# Altium schematic sheet power.SchDoc(power)
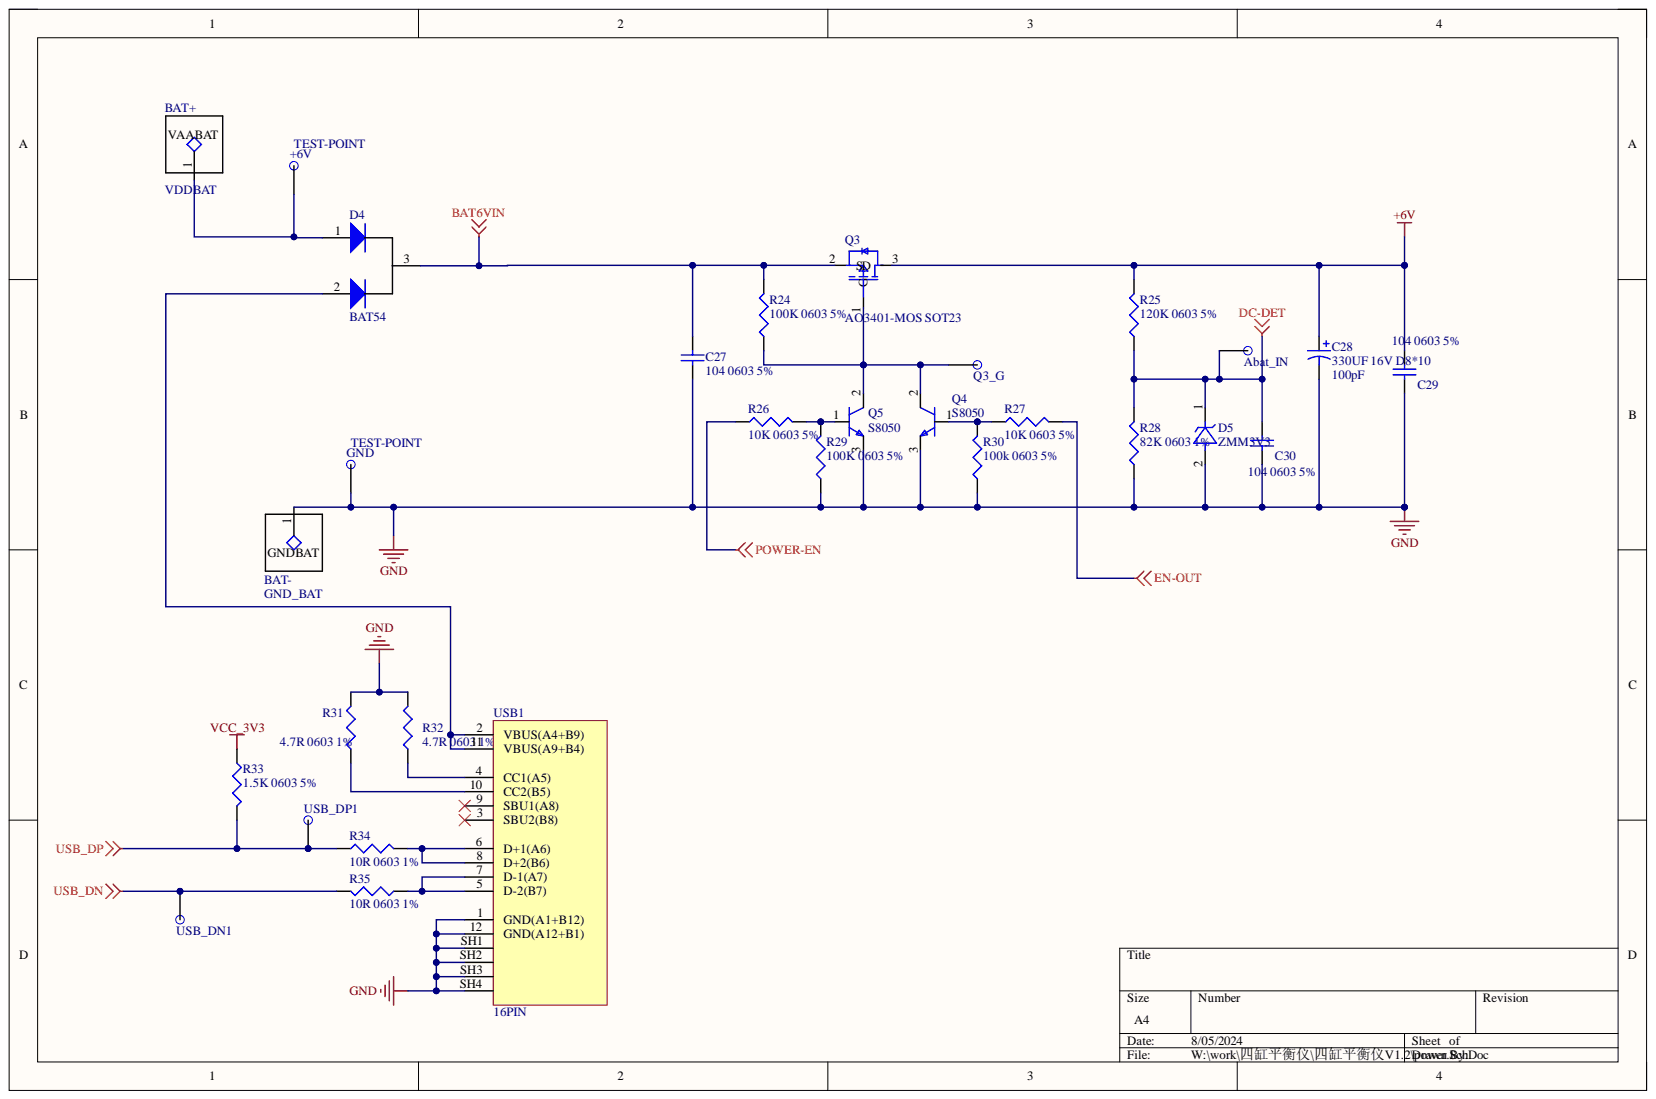
[diagram p0: power.SchDoc]

## Parts
+6V  TEST-POINT  [tp]
Abat_IN  TEST-POINT  [tp]
BAT+  VDDBAT  [VAABAT]
BAT-  GND_BAT  [VAABAT]
C27  104 0603 5%  封装: 0603  [CAP0603_3D]
C28  330UF 16V D8*10  value 100pF  [贴片电解D8*10.2]
C29  104 0603 5%  封装: 0603  [CAP0603_3D]
C30  104 0603 5%  封装: 0603  [CAP0603_3D]
D4  BAT54  [SOT23-1-0.8]
D5  ZMM3V3  [LL34]
GND  TEST-POINT  [tp]
Q3  AO3401-MOS SOT23  [SOT23-1-0.8]
Q3_G  TEST-POINT  [tp]
Q4  S8050  [SOT23]
Q5  S8050  [SOT23]
R24  100K 0603 5%  [RES0603_3D]
R25  120K 0603 5%  [RES0603_3D]
R26  10K 0603 5%  [RES0603_3D]
R27  10K 0603 5%  [RES0603_3D]
R28  82K 0603 1%  [RES0603_3D]
R29  100K 0603 5%  [RES0603_3D]
R30  100k 0603 5%  [RES0603_3D]
R31  4.7R 0603 1%  精度误差: 1%  [RES0603_3D]
R32  4.7R 0603 1%  [RES0603_3D]
R33  1.5K 0603 5%  [RES0603_3D]
R34  10R 0603 1%  精度误差: 1%  [RES0603_3D]
R35  10R 0603 1%  精度误差: 1%  [RES0603_3D]
USB1  16PIN  [TYPE-C 6.5mm高]
USB_DN1  TEST-POINT  [tp]
USB_DP1  TEST-POINT  [tp]

## Nets
+6V: C28.1 C29.2 Q3.3 R25.2
BAT6VIN: C27.1 D4.3 Q3.2 R24.2
DC-DET: Abat_IN.1 C30.2 D5.1 R25.1 R28.2
EN-OUT: R27.2
GND: BAT-.1 C27.2 C28.2 C29.1 C30.1 D5.2 GND.1 Q4.3 Q5.3 R28.1 R29.1 R30.1 R31.2 R32.2 USB1.1(GND(A1+B12)) USB1.12(GND(A12+B1)) USB1.SH1 USB1.SH2 USB1.SH3 USB1.SH4
POWER-EN: R26.1
USB_DN: R35.2 USB_DN1.1
USB_DP: R33.2 R34.1 USB_DP1.1
VCC_3V3: R33.1
Net+6V_1: +6V.1 BAT+.1 D4.1
NetD4_2: D4.2 USB1.2(VBUS(A4+B9)) USB1.11(VBUS(A9+B4))
NetQ3_1: Q3.1 Q3_G.1 Q4.2 Q5.2 R24.1
NetQ4_1: Q4.1 R27.1 R30.2
NetQ5_1: Q5.1 R26.2 R29.2
NetR31_1: R31.1 USB1.10(CC2(B5))
NetR32_1: R32.1 USB1.4(CC1(A5))
NetR34_2: R34.2 USB1.6(D+1(A6)) USB1.8(D+2(B6))
NetR35_1: R35.1 USB1.5(D-2(B7)) USB1.7(D-1(A7))

Not connected: USB1 3(SBU2(B8)) 9(SBU1(A8))

## Library data of the parts
C27 C29 C30 = CAP_SMT: 贴片多层陶瓷电容; 容值误差: 10%; 耐压: 50V; Component Kind: Standard; Library Name: hwt.SchLib
C28 = Cap Pol1: Polarized Capacitor (Radial); Component Kind: Standard; LatestRevisionDate: 17-Jul-2002; LatestRevisionNote: Re-released for DXP Platform.; Library Name: Miscellaneous Devices.IntLib; PackageDescription: Polarized Capacitor (Radial); 2 Leads; PackageReference: RB7.6-15; Published: 8-Jun-2000; Signal Integrity: Cap Pol; Simulation: CAP; Publisher: Altium Limited
D4 = 复合二极管SOT-23: Component Kind: Standard; Library Name: hwt.SchLib
R24-R27 R29 R30 R33 = RES_SMT: 精度误差: 1%; Component Kind: Standard; Library Name: hwt.SchLib
R28 R31 R32 R34 R35 = RES_SMT: Component Kind: Standard; Library Name: hwt.SchLib
  R28 R32: 精度误差: 1%
USB1 = TYPE-C 16pin: Component Kind: Standard; Library Name: hhh.SCHLIB
+6V Abat_IN GND Q3_G USB_DN1 USB_DP1 = TEST-POINT: Component Kind: Standard; Library Name: hwt.SchLib
BAT+ = VDDBAT: Component Kind: Standard; Library Name: hwt.SchLib
BAT- = GND_BAT: Component Kind: Standard; Library Name: hwt.SchLib
D5 = ZMM3V3: Component Kind: Standard; Library Name: hhh.SCHLIB
Q3 = AO3401-MOS SOT23: Component Kind: Standard; Library Name: hhh.SCHLIB
Q4 Q5 = S8050: Component Kind: Standard; Library Name: hhh.SCHLIB
